# Altium schematic sheet LED_SW_BUT.SchDoc(LED_SW_BUT)
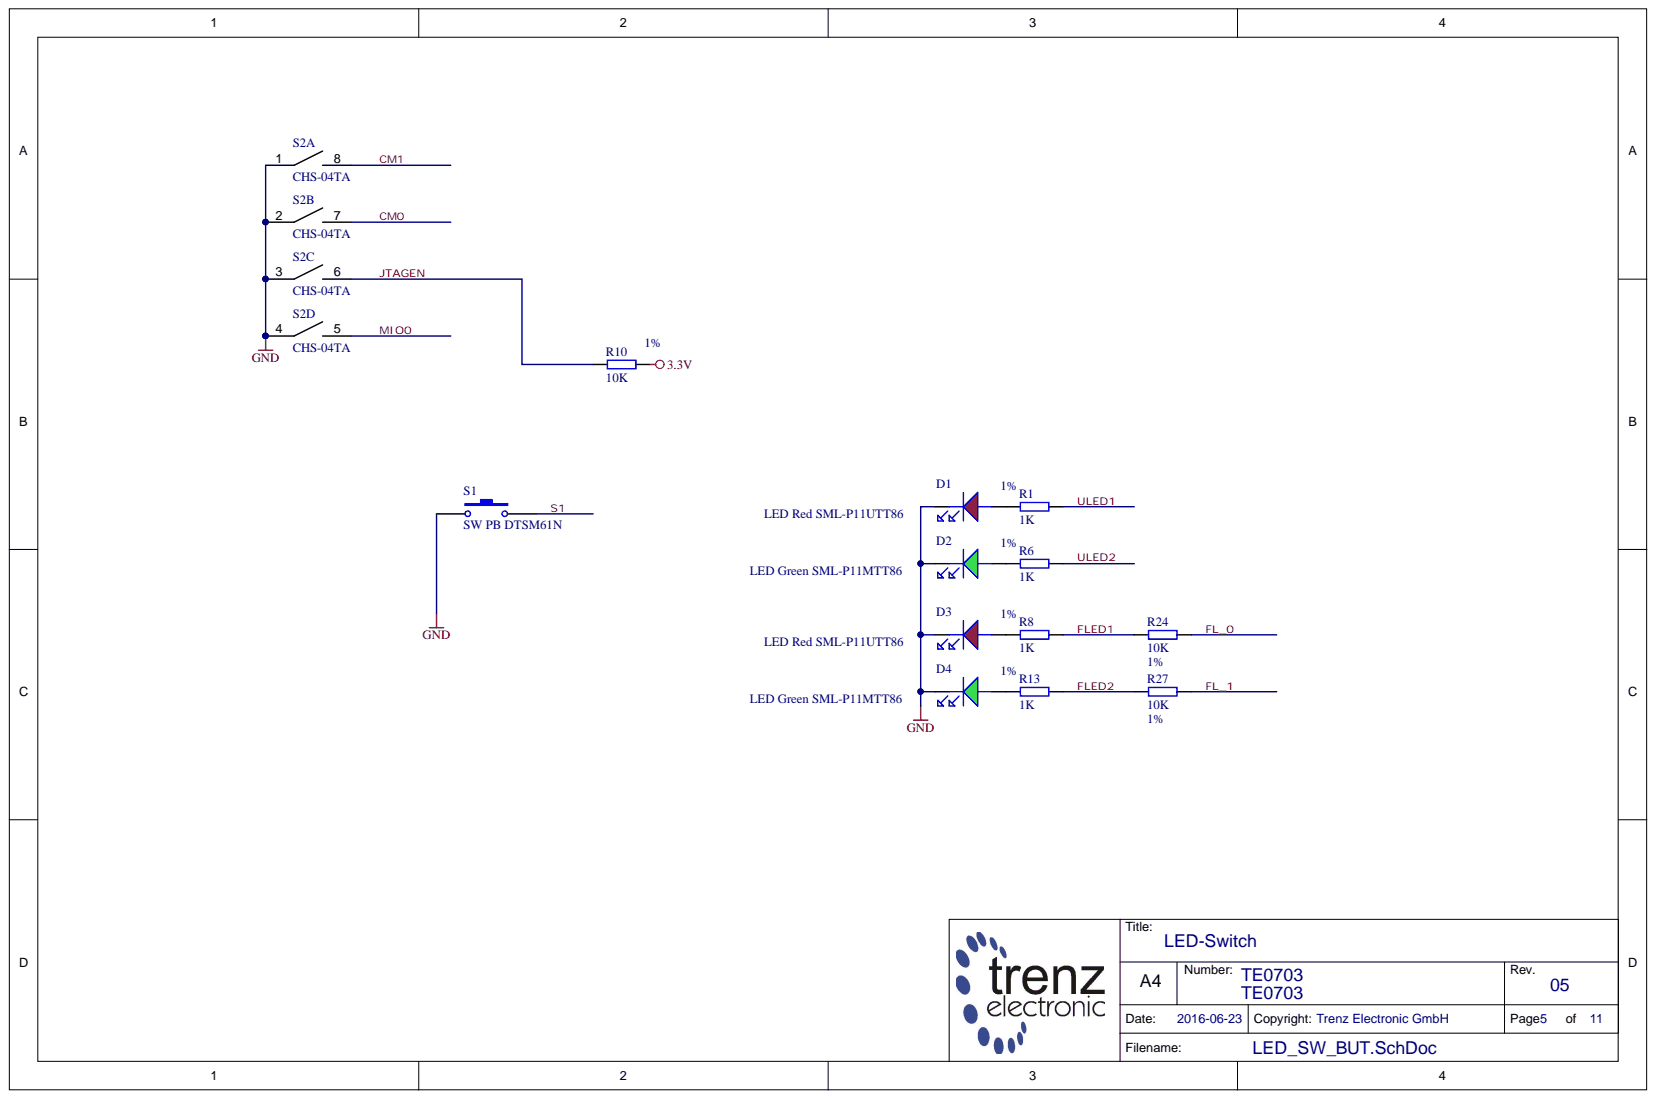
[diagram p0: LED_SW_BUT.SchDoc]

## Parts
D1  LED Red SML-P11UTT86  [LEDC1006X25N_RED]
D2  LED Green SML-P11MTT86  [LEDC1006X25N_GREEN]
D3  LED Red SML-P11UTT86  [LEDC1006X25N_RED]
D4  LED Green SML-P11MTT86  [LEDC1006X25N_GREEN]
R1  1K  Tolerance: 1%  [RESC1005X40N]
R6  1K  Tolerance: 1%  [RESC1005X40N]
R8  1K  Tolerance: 1%  [RESC1005X40N]
R10  10K  Tolerance: 1%  [RESC1005X40N]
R13  1K  Tolerance: 1%  [RESC1005X40N]
R24  10K  [RESC1005X40N]
R27  10K  Tolerance: 1%  [RESC1005X40N]
S1  SW PB DTSM61N  [DTSM61N]
S2  CHS-04TA  [CHS-04TA]  (parts A,B,C,D)

## Nets
3.3V: R10.1
GND: D1.K D2.K D3.K D4.K S1.1 S2.1 S2.2 S2.3 S2.4
CM0: S2.7
CM1: S2.8
FL_0: R24.2
FL_1: R27.2
FLED1: R8.2 R24.1
FLED2: R13.2 R27.1
JTAGEN: R10.2 S2.6
MIO0: S2.5
S1: S1.2
ULED1: R1.2
ULED2: R6.2
NetD1_A: D1.A R1.1
NetD2_A: D2.A R6.1
NetD3_A: D3.A R8.1
NetD4_A: D4.A R13.1

## Library data of the parts
D1 D3 = 24212: LED Rot SML-P11UTT86 SMD; Mixed: SMT; Preferred: Yes; AN: 24212; Case: 1005 (0402); Component Kind: Standard; Database Table Name: LED; H-ART: SML-P11UTT86; Library Name: TE.DbLib; HelpURL: B:\AD\adlib\datasheet\SML-P1
D2 D4 = 24211: LED Grün SML-P11MTT86 SMD; Mixed: SMT; Preferred: Yes; AN: 24211; Case: 1005 (0402); Component Kind: Standard; Database Table Name: LED; H-ART: SML-P11MTT86; Library Name: TE.DbLib; HelpURL: B:\AD\adlib\datasheet\SML-P1
R1 R6 R8 R13 = 22752: WID SMD 1K 1% 0,063W; Database Table Name: R_1005_0402; Mixed: SMT; Preferred: Yes; AN: 22752; Case: 1005 (0402); Component Kind: Standard; Library Name: TE.DbLib; Technology: TK100; Value: 1K
R10 R24 R27 = 22750: WID SMD 10K 1% 0,063W; Database Table Name: R_1005_0402; Mixed: SMT; Preferred: Yes; AN: 22750; Case: 1005 (0402); Component Kind: Standard; Library Name: TE.DbLib; Technology: TK100; Value: 10K
  R24: Tolerance: 1%
S1 = 22596: Taster Miniatur Leiterplatte 1.6N; Database Table Name: PB_SW; Mixed: SMT; Preferred: Yes; AN: 22596; Component Kind: Standard; H-ART: DTSM61N; Library Name: TE.DbLib; Trenz-Stock: Yes; HelpURL: B:\AD\adlib\datasheet\DTSM
S2 = 23417: DIP Schalter 4 fach 1,27mm SMD; Database Table Name: PB_SW; Mixed: SMT; Preferred: Yes; AN: 23417; Component Kind: Standard; H-ART: CHS-04TA; Library Name: TE.DbLib; Trenz-Stock: Yes; HelpURL: B:\AD\adlib\datasheet\CHS
